# Altium schematic sheet 419-005-01-02_PG 08 - CV SoC - Power.SchDoc("419-005-01-02_PG 08 - CV SoC - Power")
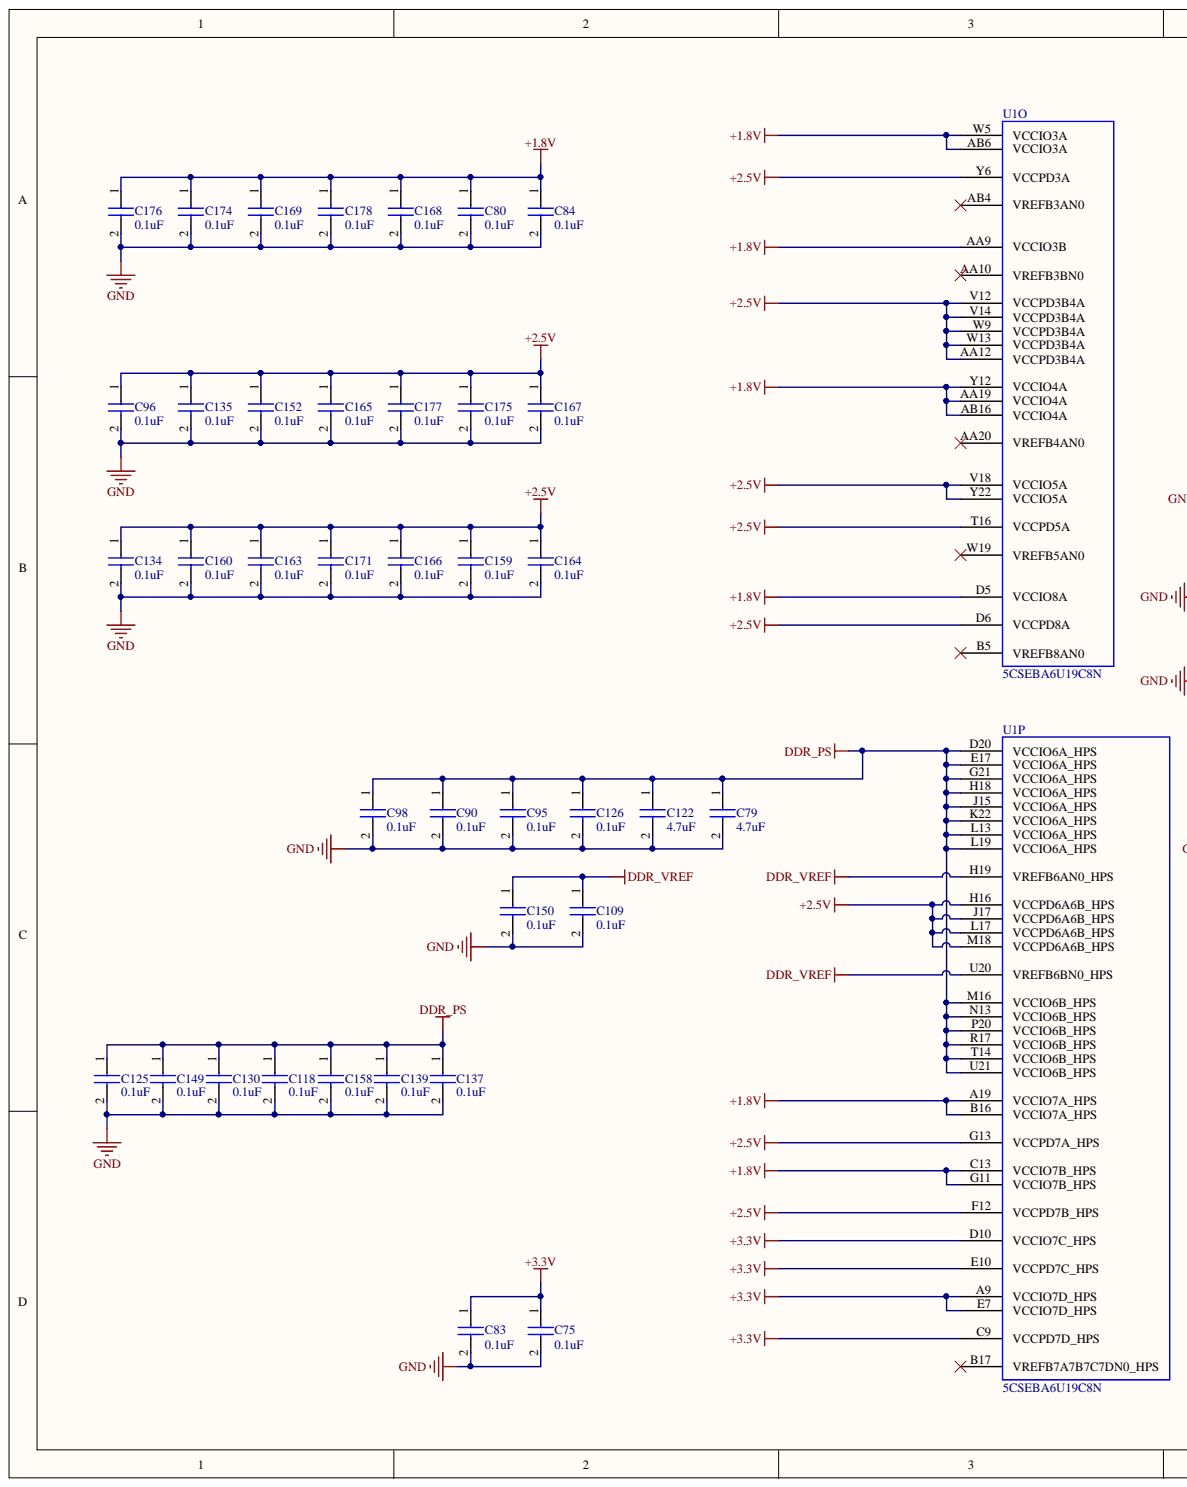
[diagram p0: 419-005-01-02_PG 08 - CV SoC - Power.SchDoc, left]
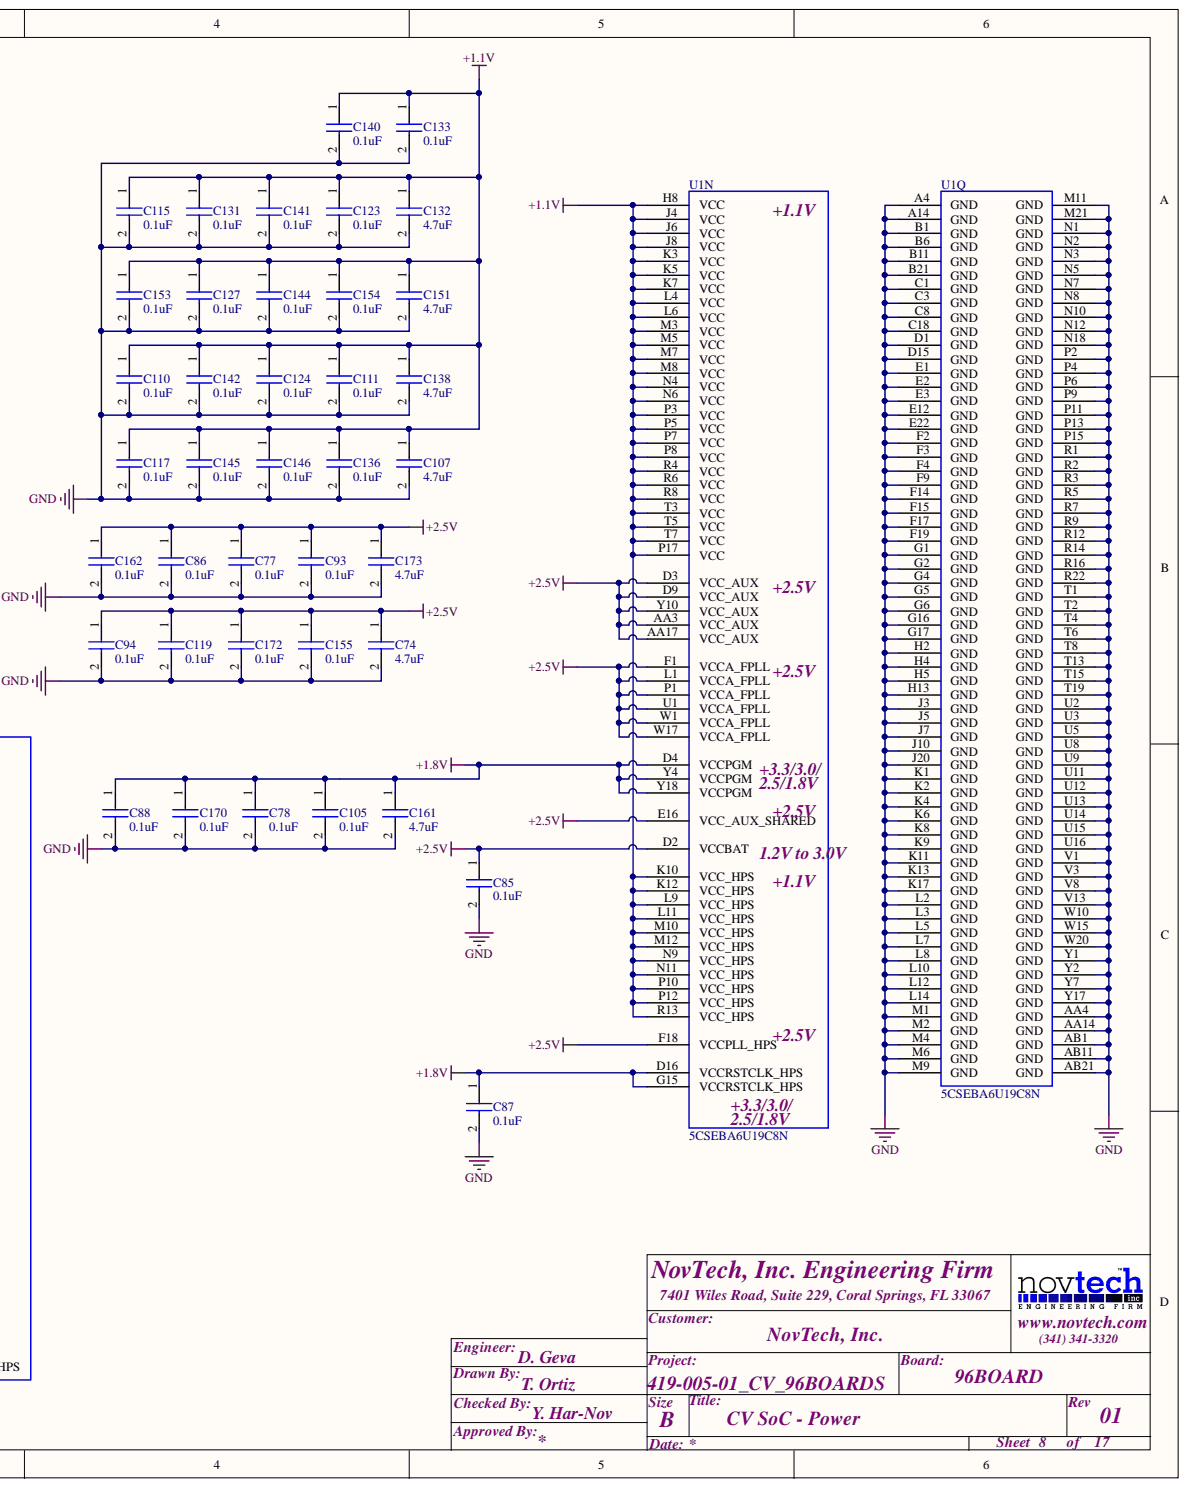
[diagram p1: 419-005-01-02_PG 08 - CV SoC - Power.SchDoc, right]

## Parts
C74  4.7uF  [0402_C]
C75  0.1uF  [0201_C]
C77  0.1uF  [0201_C]
C78  0.1uF  [0201_C]
C79  4.7uF  [0402_C]
C80  0.1uF  [0201_C]
C83  0.1uF  [0201_C]
C84  0.1uF  [0201_C]
C85  0.1uF  [0201_C]
C86  0.1uF  [0201_C]
C87  0.1uF  [0201_C]
C88  0.1uF  [0201_C]
C90  0.1uF  [0201_C]
C93  0.1uF  [0201_C]
C94  0.1uF  [0201_C]
C95  0.1uF  [0201_C]
C96  0.1uF  [0201_C]
C98  0.1uF  [0201_C]
C105  0.1uF  [0201_C]
C107  4.7uF  [0402_C]
C109  0.1uF  [0201_C]
C110  0.1uF  [0201_C]
C111  0.1uF  [0201_C]
C115  0.1uF  [0201_C]
C117  0.1uF  [0201_C]
C118  0.1uF  [0201_C]
C119  0.1uF  [0201_C]
C122  4.7uF  [0402_C]
C123  0.1uF  [0201_C]
C124  0.1uF  [0201_C]
C125  0.1uF  [0201_C]
C126  0.1uF  [0201_C]
C127  0.1uF  [0201_C]
C130  0.1uF  [0201_C]
C131  0.1uF  [0201_C]
C132  4.7uF  [0402_C]
C133  0.1uF  [0201_C]
C134  0.1uF  [0201_C]
C135  0.1uF  [0201_C]
C136  0.1uF  [0201_C]
C137  0.1uF  [0201_C]
C138  4.7uF  [0402_C]
C139  0.1uF  [0201_C]
C140  0.1uF  [0201_C]
C141  0.1uF  [0201_C]
C142  0.1uF  [0201_C]
C144  0.1uF  [0201_C]
C145  0.1uF  [0201_C]
C146  0.1uF  [0201_C]
C149  0.1uF  [0201_C]
C150  0.1uF  [0201_C]
C151  4.7uF  [0402_C]
C152  0.1uF  [0201_C]
C153  0.1uF  [0201_C]
C154  0.1uF  [0201_C]
C155  0.1uF  [0201_C]
C158  0.1uF  [0201_C]
C159  0.1uF  [0201_C]
C160  0.1uF  [0201_C]
C161  4.7uF  [0402_C]
C162  0.1uF  [0201_C]
C163  0.1uF  [0201_C]
C164  0.1uF  [0201_C]
C165  0.1uF  [0201_C]
C166  0.1uF  [0201_C]
C167  0.1uF  [0201_C]
C168  0.1uF  [0201_C]
C169  0.1uF  [0201_C]
C170  0.1uF  [0201_C]
C171  0.1uF  [0201_C]
C172  0.1uF  [0201_C]
C173  4.7uF  [0402_C]
C174  0.1uF  [0201_C]
C175  0.1uF  [0201_C]
C176  0.1uF  [0201_C]
C177  0.1uF  [0201_C]
C178  0.1uF  [0201_C]
U1  5CSEBA6U19C8N  [BGA484C80P22X22_1900X1900X190]  (parts N,O,P,Q)

## Nets
+1.1V: C107.1 C110.1 C111.1 C115.1 C117.1 C123.1 C124.1 C127.1 C131.1 C132.1 C133.1 C136.1 C138.1 C140.1 C141.1 C142.1 C144.1 C145.1 C146.1 C151.1 C153.1 C154.1 U1.H8(VCC) U1.J4(VCC) U1.J6(VCC) U1.J8(VCC) U1.K3(VCC) U1.K5(VCC) U1.K7(VCC) U1.K10(VCC_HPS) U1.K12(VCC_HPS) U1.L4(VCC) U1.L6(VCC) U1.L9(VCC_HPS) U1.L11(VCC_HPS) U1.M3(VCC) U1.M5(VCC) U1.M7(VCC) U1.M8(VCC) U1.M10(VCC_HPS) U1.M12(VCC_HPS) U1.N4(VCC) U1.N6(VCC) U1.N9(VCC_HPS) U1.N11(VCC_HPS) U1.P3(VCC) U1.P5(VCC) U1.P7(VCC) U1.P8(VCC) U1.P10(VCC_HPS) U1.P12(VCC_HPS) U1.P17(VCC) U1.R4(VCC) U1.R6(VCC) U1.R8(VCC) U1.R13(VCC_HPS) U1.T3(VCC) U1.T5(VCC) U1.T7(VCC)
+1.8V: C78.1 C80.1 C84.1 C87.1 C88.1 C105.1 C161.1 C168.1 C169.1 C170.1 C174.1 C176.1 C178.1 U1.A19(VCCIO7A_HPS) U1.AA9(VCCIO3B) U1.AA19(VCCIO4A) U1.AB6(VCCIO3A) U1.AB16(VCCIO4A) U1.B16(VCCIO7A_HPS) U1.C13(VCCIO7B_HPS) U1.D4(VCCPGM) U1.D5(VCCIO8A) U1.D16(VCCRSTCLK_HPS) U1.G11(VCCIO7B_HPS) U1.G15(VCCRSTCLK_HPS) U1.W5(VCCIO3A) U1.Y4(VCCPGM) U1.Y12(VCCIO4A) U1.Y18(VCCPGM)
+2.5V: C74.1 C77.1 C85.1 C86.1 C93.1 C94.1 C96.1 C119.1 C134.1 C135.1 C152.1 C155.1 C159.1 C160.1 C162.1 C163.1 C164.1 C165.1 C166.1 C167.1 C171.1 C172.1 C173.1 C175.1 C177.1 U1.AA3(VCC_AUX) U1.AA12(VCCPD3B4A) U1.AA17(VCC_AUX) U1.D2(VCCBAT) U1.D3(VCC_AUX) U1.D6(VCCPD8A) U1.D9(VCC_AUX) U1.E16(VCC_AUX_SHARED) U1.F1(VCCA_FPLL) U1.F12(VCCPD7B_HPS) U1.F18(VCCPLL_HPS) U1.G13(VCCPD7A_HPS) U1.H16(VCCPD6A6B_HPS) U1.J17(VCCPD6A6B_HPS) U1.L1(VCCA_FPLL) U1.L17(VCCPD6A6B_HPS) U1.M18(VCCPD6A6B_HPS) U1.P1(VCCA_FPLL) U1.T16(VCCPD5A) U1.U1(VCCA_FPLL) U1.V12(VCCPD3B4A) U1.V14(VCCPD3B4A) U1.V18(VCCIO5A) U1.W1(VCCA_FPLL) U1.W9(VCCPD3B4A) U1.W13(VCCPD3B4A) U1.W17(VCCA_FPLL) U1.Y6(VCCPD3A) U1.Y10(VCC_AUX) U1.Y22(VCCIO5A)
+3.3V: C75.1 C83.1 U1.A9(VCCIO7D_HPS) U1.C9(VCCPD7D_HPS) U1.D10(VCCIO7C_HPS) U1.E7(VCCIO7D_HPS) U1.E10(VCCPD7C_HPS)
DDR_PS: C79.1 C90.1 C95.1 C98.1 C118.1 C122.1 C125.1 C126.1 C130.1 C137.1 C139.1 C149.1 C158.1 U1.D20(VCCIO6A_HPS) U1.E17(VCCIO6A_HPS) U1.G21(VCCIO6A_HPS) U1.H18(VCCIO6A_HPS) U1.J15(VCCIO6A_HPS) U1.K22(VCCIO6A_HPS) U1.L13(VCCIO6A_HPS) U1.L19(VCCIO6A_HPS) U1.M16(VCCIO6B_HPS) U1.N13(VCCIO6B_HPS) U1.P20(VCCIO6B_HPS) U1.R17(VCCIO6B_HPS) U1.T14(VCCIO6B_HPS) U1.U21(VCCIO6B_HPS)
DDR_VREF: C109.1 C150.1 U1.H19(VREFB6AN0_HPS) U1.U20(VREFB6BN0_HPS)
GND: C74.2 C75.2 C77.2 C78.2 C79.2 C80.2 C83.2 C84.2 C85.2 C86.2 C87.2 C88.2 C90.2 C93.2 C94.2 C95.2 C96.2 C98.2 C105.2 C107.2 C109.2 C110.2 C111.2 C115.2 C117.2 C118.2 C119.2 C122.2 C123.2 C124.2 C125.2 C126.2 C127.2 C130.2 C131.2 C132.2 C133.2 C134.2 C135.2 C136.2 C137.2 C138.2 C139.2 C140.2 C141.2 C142.2 C144.2 C145.2 C146.2 C149.2 C150.2 C151.2 C152.2 C153.2 C154.2 C155.2 C158.2 C159.2 C160.2 C161.2 C162.2 C163.2 C164.2 C165.2 C166.2 C167.2 C168.2 C169.2 C170.2 C171.2 C172.2 C173.2 C174.2 C175.2 C176.2 C177.2 C178.2 U1.A4(GND) U1.A14(GND) U1.AA4(GND) U1.AA14(GND) U1.AB1(GND) U1.AB11(GND) U1.AB21(GND) U1.B1(GND) U1.B6(GND) U1.B11(GND) U1.B21(GND) U1.C1(GND) U1.C3(GND) U1.C8(GND) U1.C18(GND) U1.D1(GND) U1.D15(GND) U1.E1(GND) U1.E2(GND) U1.E3(GND) U1.E12(GND) U1.E22(GND) U1.F2(GND) U1.F3(GND) U1.F4(GND) U1.F9(GND) U1.F14(GND) U1.F15(GND) U1.F17(GND) U1.F19(GND) U1.G1(GND) U1.G2(GND) U1.G4(GND) U1.G5(GND) U1.G6(GND) U1.G16(GND) U1.G17(GND) U1.H2(GND) U1.H4(GND) U1.H5(GND) U1.H13(GND) U1.J3(GND) U1.J5(GND) U1.J7(GND) U1.J10(GND) U1.J20(GND) U1.K1(GND) U1.K2(GND) U1.K4(GND) U1.K6(GND) U1.K8(GND) U1.K9(GND) U1.K11(GND) U1.K13(GND) U1.K17(GND) U1.L2(GND) U1.L3(GND) U1.L5(GND) U1.L7(GND) U1.L8(GND) U1.L10(GND) U1.L12(GND) U1.L14(GND) U1.M1(GND) U1.M2(GND) U1.M4(GND) U1.M6(GND) U1.M9(GND) U1.M11(GND) U1.M21(GND) U1.N1(GND) U1.N2(GND) U1.N3(GND) U1.N5(GND) U1.N7(GND) U1.N8(GND) U1.N10(GND) U1.N12(GND) U1.N18(GND) U1.P2(GND) U1.P4(GND) U1.P6(GND) U1.P9(GND) U1.P11(GND) U1.P13(GND) U1.P15(GND) U1.R1(GND) U1.R2(GND) U1.R3(GND) U1.R5(GND) U1.R7(GND) U1.R9(GND) U1.R12(GND) U1.R14(GND) U1.R16(GND) U1.R22(GND) U1.T1(GND) U1.T2(GND) U1.T4(GND) U1.T6(GND) U1.T8(GND) U1.T13(GND) U1.T15(GND) U1.T19(GND) U1.U2(GND) U1.U3(GND) U1.U5(GND) U1.U8(GND) U1.U9(GND) U1.U11(GND) U1.U12(GND) U1.U13(GND) U1.U14(GND) U1.U15(GND) U1.U16(GND) U1.V1(GND) U1.V3(GND) U1.V8(GND) U1.V13(GND) U1.W10(GND) U1.W15(GND) U1.W20(GND) U1.Y1(GND) U1.Y2(GND) U1.Y7(GND) U1.Y17(GND)

Not connected: U1 AA10(VREFB3BN0) AA20(VREFB4AN0) AB4(VREFB3AN0) B5(VREFB8AN0) B17(VREFB7A7B7C7DN0_HPS) W19(VREFB5AN0)

## Library data of the parts
C74 C79 C107 C122 C132 C138 C151 C161 C173 = CAP_0402: Capasitor 0402 footprint; Bottom: 10; Right: 20; Component Kind: Standard; Library Name: PASSIVES.SchLib
C75 C77 C78 C80 C83-C88 C90 C93-C96 C98 C105 C109-C111 C115 C117-C119 C123-C127 C130 C131 C133-C137 C139-C142 C144-C146 C149 C150 C152-C155 C158-C160 C162-C172 C174-C178 = CAP_0201: Capasitor 0201 footprint; Component Kind: Standard; Library Name: PASSIVES.SchLib
U1 = 5CSEBA6U19C8N: Cyclone V SE Family FPGA, 1.07 to 1.13 V Supply, 66 I/O Pins, 6 PLLs, 484-Pin UBGA, Speed Grade 7, Commercial Grade, RoHS Compliant; Manufacturer: Altera; Logic Elements (LE) (K): 110; Speed Grade: 8; Code_JEDEC: MO-275; Component Kind: Standard; ComponentLink1Description: Manufacturer URL; ComponentLink1URL: http://www.altera.com; ComponentLink2Description: Datasheet; ComponentLink2URL: http://www.altera.com/literature/hb/cyclone-v/cv_51002.pdf; ComponentLink3Description: Package Specification; ComponentLink3URL: http://www.altera.com/devicepackaging/04R00436-01.pdf; ComponentLink4Description: Device Handbook URL; ComponentLink4URL: http://www.altera.com/literature/hb/cyclone-v/cyclone5_handbook.pdf; ComponentLink5Description: Device Pin-Out URL; ComponentLink5URL: http://www.altera.com/literature/dp/cyclone-v/5CSEBA6.xls; ComponentLink6Description: Product URL; ComponentLink6URL: http://www.buyaltera.com/scripts/partsearch.dll?Detail&name=5CSEBA6U19C8N-ND; DatasheetVersion: Rev. 3.4; Device Group: 5CSEBA6; Library Name: IC_SchLib.SCHLIB; Mounting Technology: Surface Mount; NexusDeviceID: 5CSEBA6U19C8N; PackageDescription: 484-Pin Ultra FineLine Ball-Grid Array (UBGA), Body 19 x 19 mm, Pitch 0.8 mm; PackageReference: UBGA484_A-190; PackageVersion: Rev. 1.0, 12/2011; PartNumber: 5CSEBA6U19C8N; RoHS: RoHS Compliant; Subcategory: Cyclone V SE; Supplier 1: Digi-Key; Supplier 2: Mouser; Supplier Part Number 1: 5CSEBA6U19C8N-ND; Supplier Part Number 2: 989-5CSEBA6U19C8N; Voltage Supply: 1.07 to 1.13 V
